# Altium schematic sheet CORE_PIN_DEF.SchDoc(CORE_PIN_DEF)
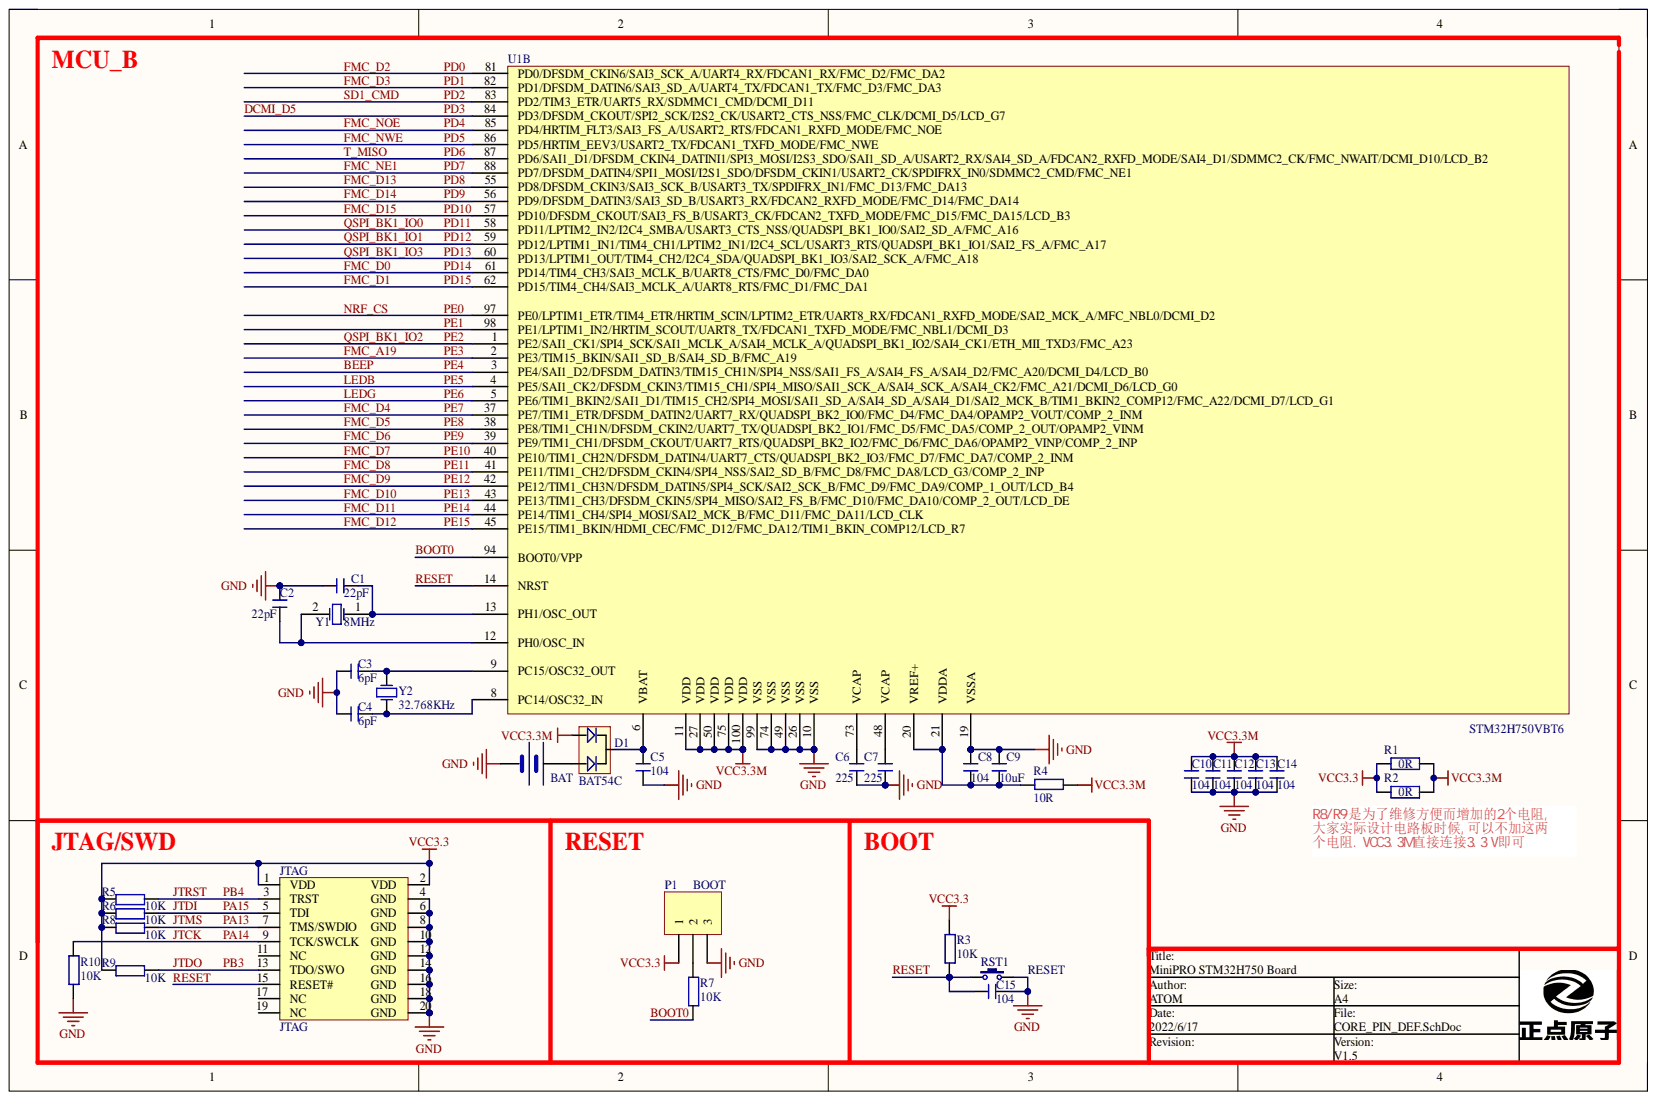
[diagram p0: CORE_PIN_DEF.SchDoc]

## Parts
BAT  BATT  [BATM]  (value not drawn)
C1  22pF  [C 0402]
C2  22pF  [C 0402]
C3  6pF  [C 0402]
C4  6pF  [C 0402]
C5  104  [C 0402]
C6  225  [C 0402]
C7  225  [C 0402]
C8  104  [C 0402]
C9  10uF  [C 0603]
C10  104  [C 0402]
C11  104  [C 0402]
C12  104  [C 0402]
C13  104  [C 0402]
C14  104  [C 0402]
C15  104  [C 0402]
D1  BAT54C  [SOT23-3L]
JTAG  JTAG  [JTAG_20]
P1  BOOT  [HDR1X3]
R1  0R  [R 0402]
R2  0R  [R 0402]
R3  10K  [0402]
R4  10R  [0402]
R5  10K  [0402]
R6  10K  [0402]
R7  10K  [0402]
R8  10K  [0402]
R9  10K  [0402]
R10  10K  [0402]
RST1  RESET  [KEY_SOP324225]
U1  STM32H750VBT6  [LQFP100]  (part B)
Y1  8MHz  [XTAL_US]
Y2  32.768KHz  [XTAL32]

## Nets
GND: BAT.2 C1.1 C2.2 C3.1 C4.1 C5.2 C6.1 C7.1 C8.1 C9.1 C10.1 C11.1 C12.1 C13.1 C14.1 C15.2 JTAG.4(GND) JTAG.6(GND) JTAG.8(GND) JTAG.10(GND) JTAG.12(GND) JTAG.14(GND) JTAG.16(GND) JTAG.18(GND) JTAG.20(GND) P1.3 R10.1 RST1.1 U1.10(VSS) U1.19(VSSA) U1.26(VSS) U1.49(VSS) U1.74(VSS) U1.99(VSS)
VCC3.3: JTAG.1(VDD) JTAG.2(VDD) P1.1 R1.1 R2.1 R3.2 R5.1 R6.1 R8.1 R9.1
VCC3.3M: C10.2 C11.2 C12.2 C13.2 C14.2 D1.1 R1.2 R2.2 R4.1 U1.11(VDD) U1.27(VDD) U1.50(VDD) U1.75(VDD) U1.100(VDD)
BEEP: U1.3(PE4/SAI1_D2/DFSDM_DATIN3/TIM15_CH1N/SPI4_NSS/SAI1_FS_A/SAI4_FS_A/SAI4_D2/FMC_A20/DCMI_D4/LCD_B0)
BOOT0: R7.1 U1.94(BOOT0/VPP)
DCMI_D5: U1.84(PD3/DFSDM_CKOUT/SPI2_SCK/I2S2_CK/USART2_CTS_NSS/FMC_CLK/DCMI_D5/LCD_G7)
FMC_A19: U1.2(PE3/TIM15_BKIN/SAI1_SD_B/SAI4_SD_B/FMC_A19)
FMC_D0: U1.61(PD14/TIM4_CH3/SAI3_MCLK_B/UART8_CTS/FMC_D0/FMC_DA0)
FMC_D1: U1.62(PD15/TIM4_CH4/SAI3_MCLK_A/UART8_RTS/FMC_D1/FMC_DA1)
FMC_D2: U1.81(PD0/DFSDM_CKIN6/SAI3_SCK_A/UART4_RX/FDCAN1_RX/FMC_D2/FMC_DA2)
FMC_D3: U1.82(PD1/DFSDM_DATIN6/SAI3_SD_A/UART4_TX/FDCAN1_TX/FMC_D3/FMC_DA3)
FMC_D4: U1.37(PE7/TIM1_ETR/DFSDM_DATIN2/UART7_RX/QUADSPI_BK2_IO0/FMC_D4/FMC_DA4/OPAMP2_VOUT/COMP_2_INM)
FMC_D5: U1.38(PE8/TIM1_CH1N/DFSDM_CKIN2/UART7_TX/QUADSPI_BK2_IO1/FMC_D5/FMC_DA5/COMP_2_OUT/OPAMP2_VINM)
FMC_D6: U1.39(PE9/TIM1_CH1/DFSDM_CKOUT/UART7_RTS/QUADSPI_BK2_IO2/FMC_D6/FMC_DA6/OPAMP2_VINP/COMP_2_INP)
FMC_D7: U1.40(PE10/TIM1_CH2N/DFSDM_DATIN4/UART7_CTS/QUADSPI_BK2_IO3/FMC_D7/FMC_DA7/COMP_2_INM)
FMC_D8: U1.41(PE11/TIM1_CH2/DFSDM_CKIN4/SPI4_NSS/SAI2_SD_B/FMC_D8/FMC_DA8/LCD_G3/COMP_2_INP)
FMC_D9: U1.42(PE12/TIM1_CH3N/DFSDM_DATIN5/SPI4_SCK/SAI2_SCK_B/FMC_D9/FMC_DA9/COMP_1_OUT/LCD_B4)
FMC_D10: U1.43(PE13/TIM1_CH3/DFSDM_CKIN5/SPI4_MISO/SAI2_FS_B/FMC_D10/FMC_DA10/COMP_2_OUT/LCD_DE)
FMC_D11: U1.44(PE14/TIM1_CH4/SPI4_MOSI/SAI2_MCK_B/FMC_D11/FMC_DA11/LCD_CLK)
FMC_D12: U1.45(PE15/TIM1_BKIN/HDMI_CEC/FMC_D12/FMC_DA12/TIM1_BKIN_COMP12/LCD_R7)
FMC_D13: U1.55(PD8/DFSDM_CKIN3/SAI3_SCK_B/USART3_TX/SPDIFRX_IN1/FMC_D13/FMC_DA13)
FMC_D14: U1.56(PD9/DFSDM_DATIN3/SAI3_SD_B/USART3_RX/FDCAN2_RXFD_MODE/FMC_D14/FMC_DA14)
FMC_D15: U1.57(PD10/DFSDM_CKOUT/SAI3_FS_B/USART3_CK/FDCAN2_TXFD_MODE/FMC_D15/FMC_DA15/LCD_B3)
FMC_NE1: U1.88(PD7/DFSDM_DATIN4/SPI1_MOSI/I2S1_SDO/DFSDM_CKIN1/USART2_CK/SPDIFRX_IN0/SDMMC2_CMD/FMC_NE1)
FMC_NOE: U1.85(PD4/HRTIM_FLT3/SAI3_FS_A/USART2_RTS/FDCAN1_RXFD_MODE/FMC_NOE)
FMC_NWE: U1.86(PD5/HRTIM_EEV3/USART2_TX/FDCAN1_TXFD_MODE/FMC_NWE)
JTCK: JTAG.9(TCK/SWCLK) R10.2
JTDI: JTAG.5(TDI) R6.2
JTDO: JTAG.13(TDO/SWO) R9.2
JTMS: JTAG.7(TMS/SWDIO) R8.2
JTRST: JTAG.3(TRST) R5.2
LEDB: U1.4(PE5/SAI1_CK2/DFSDM_CKIN3/TIM15_CH1/SPI4_MISO/SAI1_SCK_A/SAI4_SCK_A/SAI4_CK2/FMC_A21/DCMI_D6/LCD_G0)
LEDG: U1.5(PE6/TIM1_BKIN2/SAI1_D1/TIM15_CH2/SPI4_MOSI/SAI1_SD_A/SAI4_SD_A/SAI4_D1/SAI2_MCK_B/TIM1_BKIN2_COMP12/FMC_A22/DCMI_D7/LCD_G1)
NRF_CS: U1.97(PE0/LPTIM1_ETR/TIM4_ETR/HRTIM_SCIN/LPTIM2_ETR/UART8_RX/FDCAN1_RXFD_MODE/SAI2_MCK_A/MFC_NBL0/DCMI_D2)
PD2: U1.83(PD2/TIM3_ETR/UART5_RX/SDMMC1_CMD/DCMI_D11)
PD6: U1.87(PD6/SAI1_D1/DFSDM_CKIN4_DATINI1/SPI3_MOSI/I2S3_SDO/SAI1_SD_A/USART2_RX/SAI4_SD_A/FDCAN2_RXFD_MODE/SAI4_D1/SDMMC2_CK/FMC_NWAIT/DCMI_D10/LCD_B2)
PD11: U1.58(PD11/LPTIM2_IN2/I2C4_SMBA/USART3_CTS_NSS/QUADSPI_BK1_IO0/SAI2_SD_A/FMC_A16)
PD12: U1.59(PD12/LPTIM1_IN1/TIM4_CH1/LPTIM2_IN1/I2C4_SCL/USART3_RTS/QUADSPI_BK1_IO1/SAI2_FS_A/FMC_A17)
PD13: U1.60(PD13/LPTIM1_OUT/TIM4_CH2/I2C4_SDA/QUADSPI_BK1_IO3/SAI2_SCK_A/FMC_A18)
PE1: U1.98(PE1/LPTIM1_IN2/HRTIM_SCOUT/UART8_TX/FDCAN1_TXFD_MODE/FMC_NBL1/DCMI_D3)
PE2: U1.1(PE2/SAI1_CK1/SPI4_SCK/SAI1_MCLK_A/SAI4_MCLK_A/QUADSPI_BK1_IO2/SAI4_CK1/ETH_MII_TXD3/FMC_A23)
RESET: C15.1 JTAG.15(RESET#) R3.1 RST1.2 U1.14(NRST)
NetBAT_1: BAT.1 D1.2
NetC1_2: C1.2 U1.13(PH1/OSC_OUT) Y1.1
NetC2_1: C2.1 U1.12(PH0/OSC_IN) Y1.2
NetC3_2: C3.2 U1.9(PC15/OSC32_OUT) Y2.1
NetC4_2: C4.2 U1.8(PC14/OSC32_IN) Y2.2
NetC5_1: C5.1 D1.3 U1.6(VBAT)
NetC6_2: C6.2 U1.73(VCAP)
NetC7_2: C7.2 U1.48(VCAP)
NetC8_2: C8.2 C9.2 R4.2 U1.20(VREF+) U1.21(VDDA)
NetP1_2: P1.2 R7.2

Not connected: JTAG 11(NC) 17(NC) 19(NC)

## Library data of the parts
BAT = BAT: Component Kind: Standard; Library Name: MYCOMLIB.IntLib
C1-C15 = C: 无极性贴片电容; 版权归属: 源创客; BOM名称: 无极性贴片电容; Component Kind: Standard; Library Name: 电阻-电容-电感.SchLib; 学习资源: www.ooocke.com
D1 = BAT54C: 双肖特基二极管; Component Kind: Standard; Library Name: ALIENTEK_COMPONENT.IntLib
P1 = Header 3: Header, 3-Pin; Component Kind: Standard; LatestRevisionDate: 17-Jul-2002; LatestRevisionNote: Re-released for DXP Platform.; Library Name: Miscellaneous Connectors.IntLib; Signal Integrity: Connector; Publisher: Altium Limited
R1 R2 = R: 贴片电阻; 版权归属: 源创客; BOM名称: 贴片电阻; Component Kind: Standard; Library Name: 电阻-电容-电感.SchLib; 学习资源: www.ooocke.com
R3-R10 = R: Component Kind: Standard; Library Name: MYCOMLIB.IntLib
RST1 = KEY: 按键; BOM名称: 3x6轻触开关; 版权归属: 源创客; Component Kind: Standard; 学习资源: www.ooocke.com; Library Name: Lycreturn.IntLib
U1 = STM32H750VB: Component Kind: Standard; Library Name: ALIENTEK_COMPONENT.IntLib
Y1 = XTAL: Crystal Oscillator; Component Kind: Standard; LatestRevisionDate: 17-Jul-2002; LatestRevisionNote: Re-released for DXP Platform.; Library Name: Miscellaneous Devices.IntLib; Published: 8-Jun-2000; Simulation: XTAL; Publisher: Altium Limited
Y2 = XTAL: 普通晶振; Component Kind: Standard; LatestRevisionDate: 17-Jul-2002; LatestRevisionNote: Re-released for DXP Platform.; Library Name: ALIENTEK_COMPONENT.IntLib; Published: 8-Jun-2000; Publisher: Altium Limited
JTAG = JTAG: Component Kind: Standard; Library Name: MYCOMLIB.IntLib
